# Altium schematic sheet DOut_Isolator.SchDoc
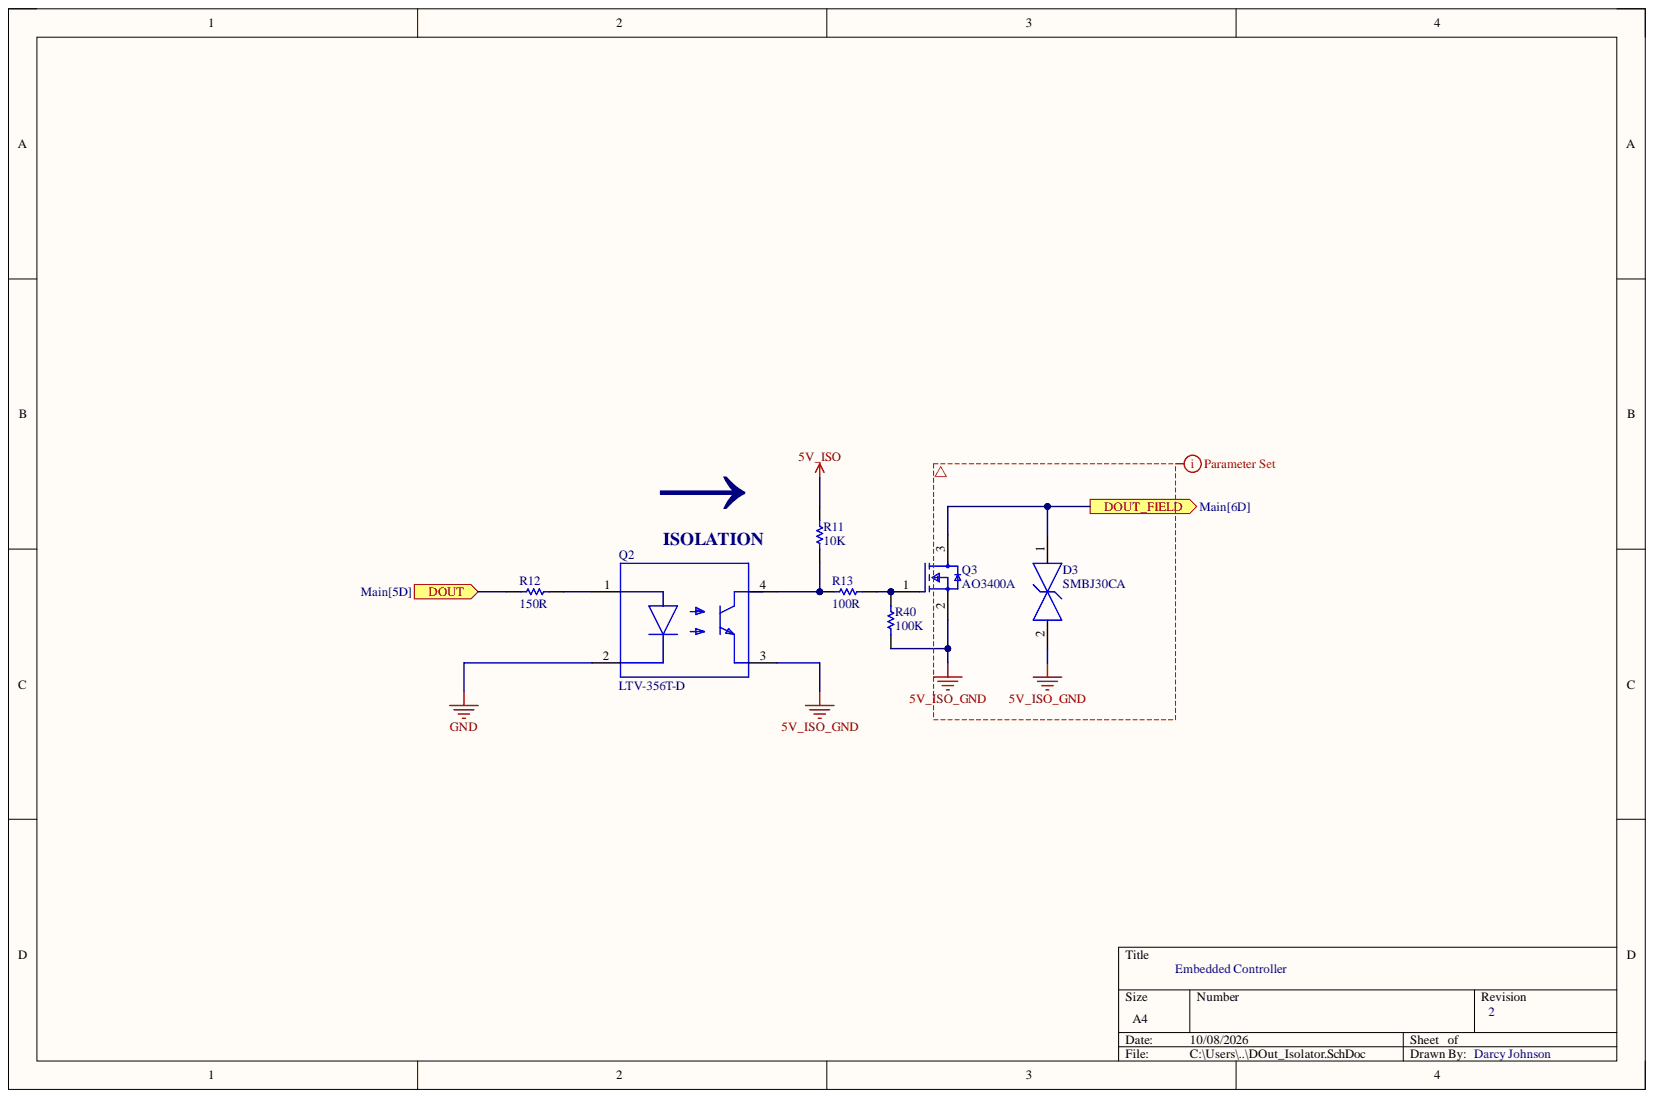
[diagram p0: DOut_Isolator.SchDoc]

## Parts
D3  SMBJ30CA  [FP-SMBJ30CAHE3-52-MFG]
Q2  LTV-356T-D  [FP-LTV-356T-D-MFG]
Q3  AO3400A  [FP-SOT23-MFG]
R11  10K  [RESC1609X55X30LL15T15]
R12  150R  [RESC1608X55X30ML20T20]
R13  100R  [RESC1609X50X30ML8T20]
R40  100K  [RESC1608X55X30ML15T15]

## Ports
DOUT, DOUT_FIELD

## Nets
5V_ISO: R11.1
5V_ISO_GND: D3.2 Q2.3 Q3.2 R40.2
GND: Q2.2
DOUT: R12.1 ; port DOUT
DOUT_FIELD: D3.1 Q3.3 ; port DOUT_FIELD
NetQ2_1: Q2.1 R12.2
NetQ2_4: Q2.4 R11.2 R13.1
NetQ3_1: Q3.1 R13.2 R40.1

## Library data of the parts
D3 = CMP-02577-000018-1: TVS DIODE 30V 48.4V DO214AA; Manufacturer: Vishay Semiconductor Diodes Division; Manufacturer Part Number: SMBJ30CAHE3/52; Automotive Grade: Grade 0; Breakdown Voltage: 33.3V; Direction: Bidirectional; Max Junction Temp: +150°C; Number Of Channels: 1; Peak Pulse Current: 12.4A; Peak Pulse Power: 600W; Standoff Height: 0mm; Automotive: Yes; Category: Diode; Clamping Voltage: 48.4V; Component Kind: Standard; ComponentLink2Description: Datasheet; ComponentLink2URL: http://www.vishay.com/docs/88392/smbj.pdf; Device Class L1: Circuit Protection; Device Class L2: TVS Diodes; Device Class L3: unset; Digikey Description: TVS DIODE 30V 48.4V DO214AA; Footprint Url: http://www.vishay.com/docs/95401/smb.pdf; Height: 2.44mm; Lead Free: Yes; Library Name: Altium Content Vault; Mouser Description: Diode TVS Single Bi-Dir 30V 600W 2-Pin DO-214AA T/R; Octopart: https://octopart.com/smbj30cahe3%2F52-vishay-43725255; Package: DO-214AA; Reverse Standoff Voltage: 30V; RoHS: TRUE; Temperature: -55°C to +150°C; Temperature Range High: +150°C; Temperature Range Low: -55°C
Q2 = CMP-22018-000003-1: OPTOCPL 1 CH TRAN OUT SMT; Manufacturer: Lite-On; Collector Emitter Voltage: 80V; Emiiter Collector Voltage: 6V; Forward Current: 20mA; Forward Voltage: 1.2V; Isolation Voltage: 3750Vrms; Max Current Transfer Ratio: 600%; Max Junction Temp: +125°C; Min Current Transfer Ratio: 300%; Power Dissipation: 170mW; Standoff Height: 0mm; Automotive: No; Category: Trans; Component Kind: Standard; ComponentLink2Description: Datasheet; ComponentLink2URL: https://ve.mouser.com/datasheet/2/239/LTV-356T%20series%20201610-1139805.pdf; Device Class L1: Optoelectronics; Device Class L2: Optocouplers; Device Class L3: unset; Digikey Description: OPTOCPL 1 CH TRAN OUT SMT; Height: 2.4mm; Lead Free: Yes; Library Name: Altium Content Vault; Manufacturer Part Number: LTV-356T-D; Octopart: https://octopart.com/ltv-356t-d-lite-on-11764243; Package: LTV-356T-D; Reverse Voltage: 6V; RoHS: TRUE; Temperature: -55°C to +110°C; Temperature Range High: +110°C; Temperature Range Low: -55°C
Q3 = CMP-83955-000006-1: MOSFET N-CH 30V 5.7A SOT23; Gate to Source Voltage (Vgs): 12V; Input Capacitance: 630pF; Max Power Dissipation: 1.4W; Number of Elements: 1; Rds On Max: 26.5mR; Case/Package: SOT-23; Component Kind: Standard; Continuous Drain Current (ID): 5.7A; Drain to Source Voltage (Vdss): 30V; Lead Free: Lead Free; Library Name: Altium Content Vault; Max Operating Temperature: 150°C; Min Operating Temperature: -55°C; Mount: Surface Mount; Number of Pins: 3; Packaging: Tape and Reel; Power Dissipation: 1.4W; Radiation Hardening: No
R11 = CMP-1012-00623-3: Chip Resistor, 10 KOhm, +/- 1%, 0.1 W, -55 to 155 degC, 0603 (1608 Metric), RoHS, Tape and Reel; Manufacturer: Panasonic; Case\/Package: 0603; Max Power Dissipation: 100mW; Resistance: 10KOhm; Temperature Coefficient: 100ppm\/degreesC; Voltage Rating: 75V; Case Code (Imperial): 0603; Case Code (Metric): 1608; Component Kind: Standard; Composition: ThickFilm; Contact Plating: Tin; Datasheet URL: https://industrial.panasonic.com/cdbs/www-data/pdf/RDA0000/AOA0000C304.pdf; Datasheet Version: 02/2017; Depth: 0.8mm; Height: 0.45mm; Lead Free: LeadFree; Length: 1.6mm; Library Name: Altium Content Vault; Manufacturer URL: http://www.panasonic.com/; Max Operating Temperature: 155degC; Military Standard: Not; Min Operating Temperature: -55degC; Mount: SurfaceMount; Mounting Technology: SurfaceMount; Number of Pins: 2; Number of Terminations: 2; Package Description: 2-Pin Surface Mount Device, Body 1.6 x 0.85 mm; Package Quantity: 1; Package Reference: 603; Packaging: TapeandReel; Power Rating: 100mW; Radiation Hardening: No; REACH SVHC: NoSVHC; RoHSCompliant: true; Termination: SMD/SMT; Tolerance: 1%; Voltage Rating (DC): 75V; Width: 0.031inch
R12 = CMP-2000-00914-1: Manufacturer: Panasonic; Part Number: ERA3AEB151V; Component Kind: Standard; Library Name: Altium Content Vault
R13 = CMP-2000-03824-5: Chip Resistor, 100 Ohm, +/- 1%, 100 mW,-55 to 155 degC, 0603 (1608 Metric), RoHS, Tape and Ree; Manufacturer: Vishay Semiconductor; Component Kind: Standard; ComponentLink1Description: Manufacturer URL; ComponentLink1URL: http://www.vishay.com/; ComponentLink2Description: Datasheet; ComponentLink2URL: http://www.vishay.com/docs/20035/dcrcwe3.pdf; DatasheetVersion: 06/2012; Library Name: Altium Content Vault; Mounting Technology: Surface Mount; PackageDescription: 2-Pin Surface Mount Device, Body 1.575 x 0.85 mm; PackageReference: 0603
R40 = CMP-2000-00182-1: Manufacturer: Panasonic; Part Number: ERJ-3EKF1003V; Component Kind: Standard; Library Name: Altium Content Vault
